# Altium schematic sheet BluePitch.SchDoc(BluePitch)
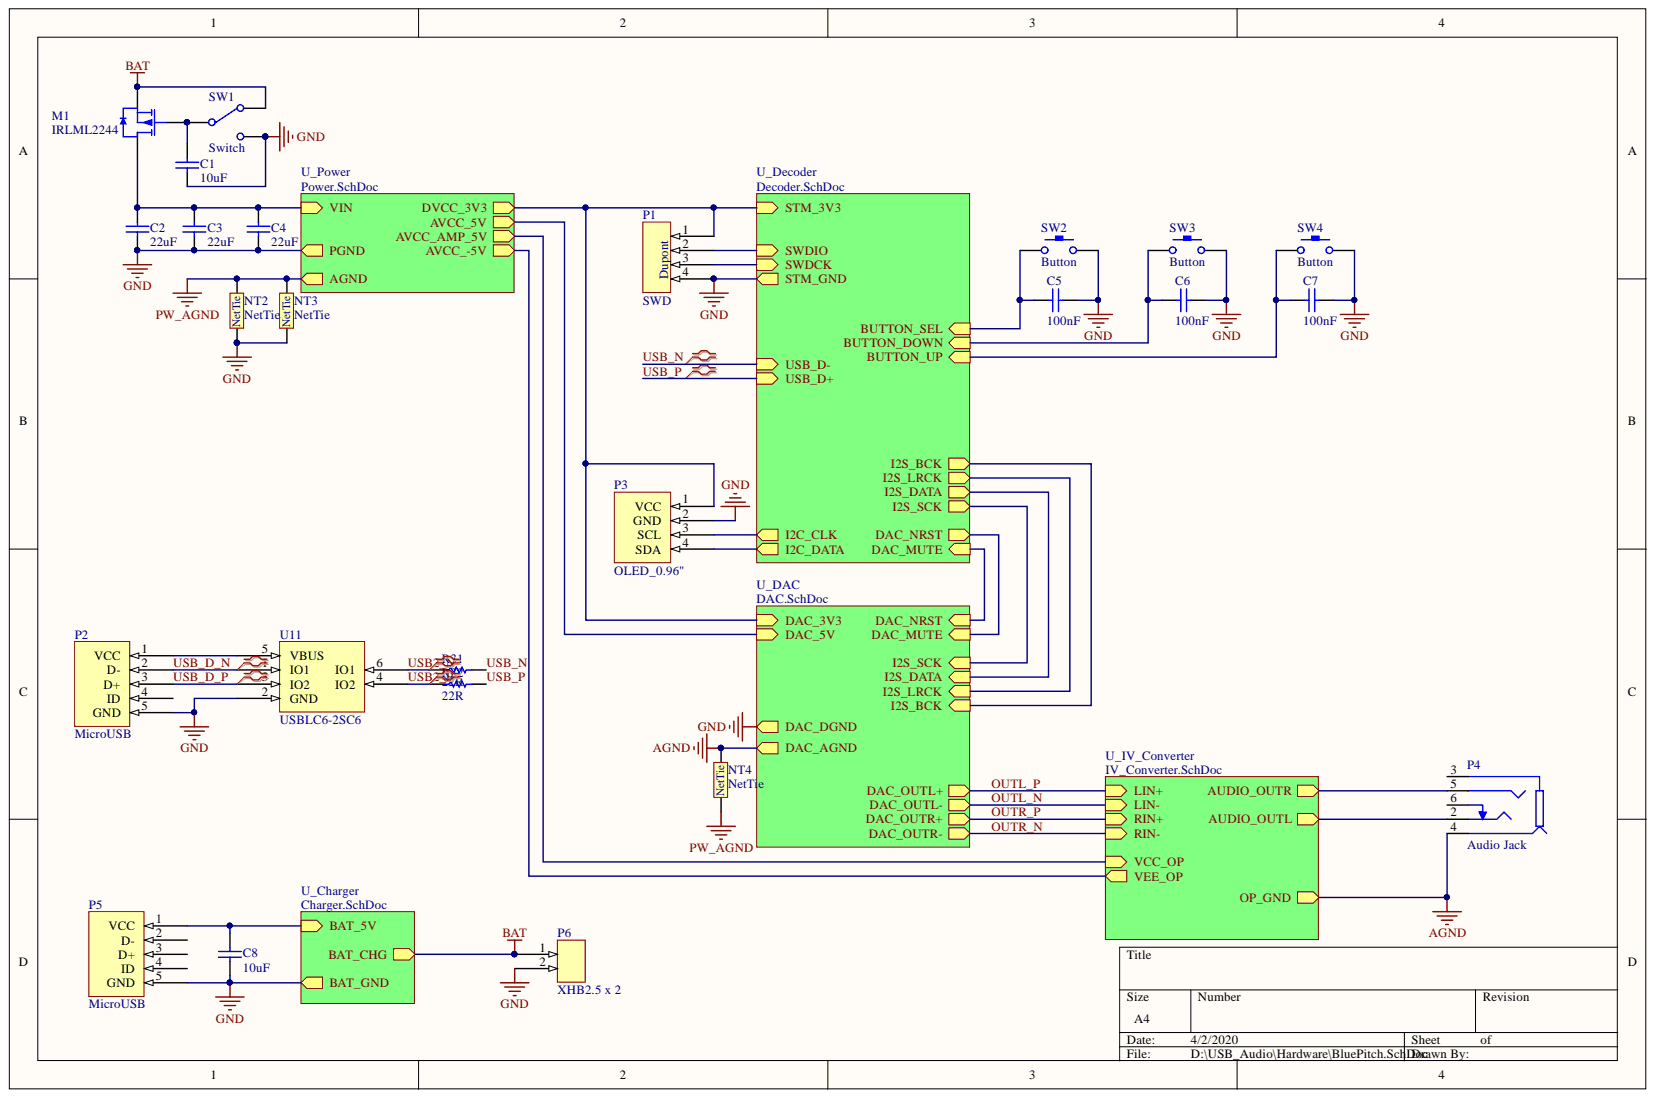
[diagram p0: BluePitch.SchDoc]

## Parts
C1  10uF  [SMC_0805]
C2  22uF  [SMC_1206]
C3  22uF  [SMC_1206]
C4  22uF  [SMC_1206]
C5  100nF  [SMC_0402]
C6  100nF  [SMC_0402]
C7  100nF  [SMC_0402]
C8  10uF  [SMC_0805]
M1  IRLML2244  [SOT95P237X112-3N]
NT2  NetTie  [NT_20]
NT3  NetTie  [NT_20]
NT4  NetTie  [NT_20]
P1  SWD  [Dupont Female x 4]
P2  MicroUSB  [MicroUSB]
P3  OLED_0.96"  [OLED 0.96"]
P4  Audio Jack  [PJ-327A]
P5  MicroUSB  [MicroUSB]
P6  XHB2.5 x 2  [XHB2.5 x 2]
R31  22R  [SMR_0402]
R32  22R  [SMR_0402]
SW1  Switch  [Switch]
SW2  Button  [Button]
SW3  Button  [Button]
SW4  Button  [Button]
U11  USBLC6-2SC6  [SOT95P280X145-6N]

## Nets
AGND: NT4.2 P4.4
BAT: M1.S P6.1 SW1.2
GND: C1.1 C2.1 C3.1 C4.1 C5.2 C6.2 C7.2 C8.1 NT2.1 NT3.1 P1.4 P2.5(GND) P3.2(GND) P5.5(GND) P6.2 SW1.3 SW2.2 SW3.2 SW4.2 U11.2(GND)
PW_AGND: NT2.2 NT3.2 NT4.1
USB2_N: R31.1 U11.6(IO1)
USB2_P: R32.1 U11.4(IO2)
USB_D_N: P2.2(D-) U11.1(IO1)
USB_D_P: P2.3(D+) U11.3(IO2)
USB_N: R31.2
USB_P: R32.2
NetC1_2: C1.2 M1.G SW1.1
NetC2_2: C2.2 C3.2 C4.2 M1.D
NetC5_1: C5.1 SW2.1
NetC6_1: C6.1 SW3.1
NetC7_1: C7.1 SW4.1
NetC8_2: C8.2 P5.1(VCC)
NetP1_1: P1.1 P3.1(VCC)
NetP1_2: P1.2
NetP1_3: P1.3
NetP2_1: P2.1(VCC) U11.5(VBUS)
NetP2_4: P2.4(ID)
NetP3_3: P3.3(SCL)
NetP3_4: P3.4(SDA)
NetP4_2: P4.2
NetP4_3: P4.3
NetP4_5: P4.5
NetP4_6: P4.6
NetP5_2: P5.2(D-)
NetP5_3: P5.3(D+)
NetP5_4: P5.4(ID)
DAC_5V:
DAC_MUTE:
DAC_NRST:
I2S_BCK:
I2S_DATA:
I2S_LRCK:
I2S_SCK:
OUTL_N:
OUTL_P:
OUTR_N:
OUTR_P:
VCC_OP:
VEE_OP:

## Library data of the parts
C1-C8 = Cap Ceramic: Library Name: Common.IntLib; Component Kind: Standard
P1 = Dupont Header x 4: Library Name: Connector.IntLib; Component Kind: Standard
R31 R32 = Res SMT: Library Name: Common.IntLib; Component Kind: Standard
M1 = IRLML2244: Library Name: BJT_MOSFET.IntLib; Component Kind: Standard
NT2-NT4 = NetTie: Library Name: Common.IntLib; Component Kind: Net Tie
P2 P5 = MicroUSB: Library Name: Connector.IntLib; Component Kind: Standard
P3 = OLED_0.96": Library Name: Connector.IntLib; Component Kind: Standard
P4 = Audio Jack: Library Name: Connector.IntLib; Component Kind: Standard
P6 = XHB2.5 x 2: Library Name: Connector.IntLib; Component Kind: Standard
SW1 = Switch: Library Name: Button_Switch.IntLib; Component Kind: Standard
SW2-SW4 = Button: Library Name: Button_Switch.IntLib; Component Kind: Standard
U11 = USBLC6-2SC6: Library Name: Interface.IntLib; Component Kind: Standard
